# Altium schematic sheet IO_section.SchDoc (continued)

## Library data of the parts
C200 C205 = C0603C100J5GACTU: 10 pF ±5% 50V Ceramic Capacitor C0G, NP0 0603 (1608 Metric); Manufacturer: KEMET; Multilayer: Yes; Capacitor Type: Ceramic; Category: Ceramic Capacitors; Component Kind: Standard; ComponentLink1Description: https://datasheet.ciiva.com:443/pdfs/VipMasterIC/IC/KEME/KEME-S-A0005605168/KEME-S-A0005605168-1.pdf?src-supplier=IHS+Markit; ComponentLink1URL: https://datasheet.ciiva.com:443/pdfs/VipMasterIC/IC/KEME/KEME-S-A0005605168/KEME-S-A0005605168-1.pdf?src-supplier=IHS+Markit; ComponentLink2Description: https://datasheet.ciiva.com/7823/1725421-7823558.pdf?src-supplier=Element14; ComponentLink2URL: https://datasheet.ciiva.com/7823/1725421-7823558.pdf?src-supplier=Element14; ComponentLink3Description: https://datasheet.ciiva.com/7823/1725421-7823559.pdf?src-supplier=Element14; ComponentLink3URL: https://datasheet.ciiva.com/7823/1725421-7823559.pdf?src-supplier=Element14; ComponentLink4Description: https://datasheet.ciiva.com/14589/70095383-14589517.pdf?src-supplier=Allied+Electronics; ComponentLink4URL: https://datasheet.ciiva.com/14589/70095383-14589517.pdf?src-supplier=Allied+Electronics; ComponentLink5Description: https://datasheet.ciiva.com/22749/1372242-22749670.pdf?src-supplier=Element14; ComponentLink5URL: https://datasheet.ciiva.com/22749/1372242-22749670.pdf?src-supplier=Element14; ComponentLink6Description: https://datasheet.ciiva.com/18192/getdatasheetpartid-299146-18192613.pdf?src-supplier=Verical; ComponentLink6URL: https://datasheet.ciiva.com/18192/getdatasheetpartid-299146-18192613.pdf?src-supplier=Verical; ComponentLink7Description: https://datasheet.ciiva.com/18192/getdatasheetpartid-179326-18192615.pdf?src-supplier=Verical; ComponentLink7URL: https://datasheet.ciiva.com/18192/getdatasheetpartid-179326-18192615.pdf?src-supplier=Verical; Dielectric Material: Ceramic; Height: 0.8mm; JESD-609 Code: e3; Length: 1.6mm; Library Name: Components.IntLib; Manufacturer Part Number: C0603C100J5GACTU; Max Operating Temperature: 125°C; Min Operating Temperature: -55°C; Mounting Technology: Surface Mount; Package Shape: Rectangular; Package Style: SMTMeter; Packaging: Tape and Reel; Pins: 2; Pricing: 1=0.14, 10=0.055, 50=0.055, 100=0.032, 1000=0.027, 10000=0.019 (USD); Rated DC Voltage (URdc): 50V; Reach SVHC Compliant: Yes; RoHS: Yes; RoHS Compliant: Yes; Stock: 425955; Supplier: Mouser; Supplier Part Number: 80-C0603C100J5G; Temperature Characteristics Code: C0G; Terminal Finish: Nickel; Termination: Wraparound; Tolerance  (fill in as +-): 5%; Tolerance (fill in as +-): 5%; Width: 0.8mm
  C200: Case/Package: 0603; Value: 10pF
C201 C204 C206 C208 C210 C213 = C0603C104K5RACTU: 0.1µF ±10% 50V Ceramic Capacitor X7R 0603 (1608 Metric); Manufacturer: KEMET; Capacitance: 100nF; Dissipation Factor: 2.5%; Case Code (Metric): 1608; Case/Package: 0603; Category: Ceramic Capacitors; Component Kind: Standard; ComponentLink1Description: https://datasheet.ciiva.com/7823/kem-c1002-x7r-smd-7823591.pdf?src-supplier=Element14; ComponentLink1URL: https://datasheet.ciiva.com/7823/kem-c1002-x7r-smd-7823591.pdf?src-supplier=Element14; ComponentLink2Description: https://datasheet.ciiva.com/14602/70095383-14602583.pdf?src-supplier=Allied+Electronics; ComponentLink2URL: https://datasheet.ciiva.com/14602/70095383-14602583.pdf?src-supplier=Allied+Electronics; ComponentLink3Description: https://datasheet.ciiva.com/5999/kem-c1002-x7r-smd-5999400.pdf?src-supplier=Farnell; ComponentLink3URL: https://datasheet.ciiva.com/5999/kem-c1002-x7r-smd-5999400.pdf?src-supplier=Farnell; ComponentLink4Description: https://datasheet.ciiva.com/7823/kem-c1002-x7r-smd-7823592.pdf?src-supplier=Element14; ComponentLink4URL: https://datasheet.ciiva.com/7823/kem-c1002-x7r-smd-7823592.pdf?src-supplier=Element14; ComponentLink5Description: https://datasheet.ciiva.com/28645/1851736-28645640.pdf?src-supplier=Element14; ComponentLink5URL: https://datasheet.ciiva.com/28645/1851736-28645640.pdf?src-supplier=Element14; ComponentLink6Description: https://datasheet.ciiva.com/14585/70095383-14585209.pdf?src-supplier=Allied+Electronics; ComponentLink6URL: https://datasheet.ciiva.com/14585/70095383-14585209.pdf?src-supplier=Allied+Electronics; Contact Plating: Tin,Matte; Depth: 0.8mm; Dielectric: X7R; Dielectric Material: Ceramic; Height: 0.8mm; Lead Pitch: 0.7mm; Length: 0.063inch; Library Name: Components.IntLib; Manufacturer Part Number: C0603C104K5RACTU; Max Operating Temperature: 125°C; Min Operating Temperature: -55°C; Mount: Surface Mount; Number of Pins: 2; Package Quantity: 1; Packaging: Tape and Reel; Pricing 1: 1=0.12000, 10=0.08900, 50=0.04840, 100=0.03940, 500=0.02818, 1000=0.02214 (USD); Radiation Hardening: No; REACH SVHC: No SVHC; RoHS: Yes; RoHS Compliant: Yes; Series: SMD Comm X7R; Stock 1: 3160568; Supplier 1: Digi-Key; Supplier Part Number 1: 399-5089-1-ND; Termination: SMD/SMT; Thickness: 0.034inch; Tolerance: 10%; Voltage: 50V; Voltage Rating: 50V; Voltage Rating (DC): 50V; Width: 0.031inch
C202 C203 C209 C211 C212 = C0603C103K5RACTU: 10000pF ±10% 50V Ceramic Capacitor X7R 0603 (1608 Metric); Manufacturer: KEMET; Dissipation Factor: 2.5%; Case Code (Metric): 1608; Case/Package: 0603; Category: Ceramic Capacitors; Component Kind: Standard; ComponentLink1Description: https://datasheet.ciiva.com/7823/1732718-7823585.pdf?src-supplier=Element14; ComponentLink1URL: https://datasheet.ciiva.com/7823/1732718-7823585.pdf?src-supplier=Element14; ComponentLink2Description: https://datasheet.ciiva.com/5904/kem-c1002-x7r-smd-5904645.pdf?src-supplier=Farnell; ComponentLink2URL: https://datasheet.ciiva.com/5904/kem-c1002-x7r-smd-5904645.pdf?src-supplier=Farnell; ComponentLink3Description: https://datasheet.ciiva.com/18192/getdatasheetpartid-308803-18192662.pdf?src-supplier=Verical; ComponentLink3URL: https://datasheet.ciiva.com/18192/getdatasheetpartid-308803-18192662.pdf?src-supplier=Verical; ComponentLink4Description: https://datasheet.ciiva.com/39122/kem-c1002-x7r-smd-39122903.pdf?src-supplier=Onlinecomponents.com; ComponentLink4URL: https://datasheet.ciiva.com/39122/kem-c1002-x7r-smd-39122903.pdf?src-supplier=Onlinecomponents.com; ComponentLink5Description: https://datasheet.ciiva.com/7823/kem-c1002-x7r-smd-7823976.pdf?src-supplier=Element14; ComponentLink5URL: https://datasheet.ciiva.com/7823/kem-c1002-x7r-smd-7823976.pdf?src-supplier=Element14; ComponentLink6Description: https://datasheet.ciiva.com/7823/kem-c1002-x7r-smd-7823977.pdf?src-supplier=Element14; ComponentLink6URL: https://datasheet.ciiva.com/7823/kem-c1002-x7r-smd-7823977.pdf?src-supplier=Element14; ComponentLink7Description: https://datasheet.ciiva.com/7823/1918693-7823978.pdf?src-supplier=Element14; ComponentLink7URL: https://datasheet.ciiva.com/7823/1918693-7823978.pdf?src-supplier=Element14; ComponentLink8Description: https://datasheet.ciiva.com/14585/70095383-14585450.pdf?src-supplier=Allied+Electronics; ComponentLink8URL: https://datasheet.ciiva.com/14585/70095383-14585450.pdf?src-supplier=Allied+Electronics; ComponentLink9Description: https://datasheet.ciiva.com/18101/getdatasheetpartid-58468-18101898.pdf?src-supplier=Verical; ComponentLink9URL: https://datasheet.ciiva.com/18101/getdatasheetpartid-58468-18101898.pdf?src-supplier=Verical; ComponentLink10Description: https://datasheet.ciiva.com/5416/kem-c1002-x7r-smd-5416028.pdf?src-supplier=Mouser; ComponentLink10URL: https://datasheet.ciiva.com/5416/kem-c1002-x7r-smd-5416028.pdf?src-supplier=Mouser; ComponentLink11Description: https://datasheet.ciiva.com/28645/1851736-28645598.pdf?src-supplier=Element14; ComponentLink11URL: https://datasheet.ciiva.com/28645/1851736-28645598.pdf?src-supplier=Element14; Contact Plating: Tin,Matte; Depth: 0.8mm; Dielectric: X7R; Dielectric Material: Ceramic; Height: 0.8mm; Lead Pitch: 0.7mm; Length: 1.6mm; Library Name: Components.IntLib; Manufacturer Part Number: C0603C103K5RACTU; Max Operating Temperature: 125°C; Min Operating Temperature: -55°C; Mount: Surface Mount,PCB; Number of Pins: 2; Package Quantity: 4000; Packaging: Tape and Reel; Pricing 1: 1=0.10000, 10=0.07000, 50=0.03820, 100=0.03130, 500=0.02234, 1000=0.01755 (USD); Radiation Hardening: No; REACH SVHC: No SVHC; RoHS: Yes; Stock 1: 1389959; Supplier 1: Digi-Key; Supplier Part Number 1: 399-1091-1-ND; Termination: SMD/SMT; Thickness: 0.034inch; Tolerance: 10%; Voltage: 50V; Voltage Rating: 50V; Voltage Rating (DC): 50V; Width: 0.8mm
  C203 C212: Case Code (Imperial): 0603
C207 = GRM188R71A225KE15J: 2.2 µF ±10% 10V Ceramic Capacitor X7R 0603 (1608 Metric); Manufacturer: Murata; Multilayer: Yes; Capacitor Type: Ceramic; Case/Package: 0603; Category: Ceramic Capacitors; Component Kind: Standard; ComponentLink1Description: https://datasheet.ciiva.com:443/pdfs/VipMasterIC/IC/MURE/MURE-S-A0000034126/MURE-S-A0000034126-1.pdf?src-supplier=IHS+Markit; ComponentLink1URL: https://datasheet.ciiva.com:443/pdfs/VipMasterIC/IC/MURE/MURE-S-A0000034126/MURE-S-A0000034126-1.pdf?src-supplier=IHS+Markit; ComponentLink2Description: https://datasheet.ciiva.com/6264/grm188r71a225ke15-01-6264252.pdf?src-supplier=Digi-Key; ComponentLink2URL: https://datasheet.ciiva.com/6264/grm188r71a225ke15-01-6264252.pdf?src-supplier=Digi-Key; ComponentLink3Description: https://datasheet.ciiva.com/6264/grm188r71a225ke15-01-6264251.pdf?src-supplier=Digi-Key; ComponentLink3URL: https://datasheet.ciiva.com/6264/grm188r71a225ke15-01-6264251.pdf?src-supplier=Digi-Key; ComponentLink4Description: https://datasheet.ciiva.com/6264/grm188r71a225ke15-01-6264253.pdf?src-supplier=Digi-Key; ComponentLink4URL: https://datasheet.ciiva.com/6264/grm188r71a225ke15-01-6264253.pdf?src-supplier=Digi-Key; Dielectric Material: Ceramic; Height: 0.8mm; JESD-609 Code: e3; Length: 1.6mm; Library Name: Components.IntLib; Manufacturer Part Number: GRM188R71A225KE15J; Max Operating Temperature: 125°C; Min Operating Temperature: -55°C; Mounting Technology: Surface Mount; Package Shape: Rectangular; Package Style: SMTMeter; Packaging: Tape and Reel; Pins: 2; Pricing: 1=0.16, 10=0.117, 50=0.0664, 100=0.055, 500=0.03858, 1000=0.03194, 2500=0.02972, 5000=0.0272 (USD); Rated DC Voltage (URdc): 10V; Reach SVHC Compliant: Yes; RoHS: Yes; RoHS Compliant: Yes; Stock: 2026591; Supplier: Digi-Key; Supplier Part Number: 490-6418-1-ND; Temperature Characteristics Code: X7R; Terminal Finish: Nickel; Termination: Wraparound; Tolerance  (fill in as +-): 10%; Tolerance (fill in as +-): 10%; Width: 0.8mm
D200 = PUSB3FR4Z: 3V (Typ) Clamp 5A (8/20µs) Ipp Tvs Diode Surface Mount DFN2510A-10; Manufacturer: Nexperia; Diode Element Material: SILICON; Diode Type: TRANS VOLTAGE SUPPRESSOR; Min Breakdown Voltage: 5.5V; Number of Elements: 4; Package Body Material: Plastic; Polarity: Unidirectional; Reference Standard: IEC-60134; Category: ESD and Circuit Protection ICs; Component Kind: Standard; ComponentLink1Description: https://datasheet.ciiva.com:443/pdfs/VipMasterIC/IC/NEXP/NEXP-S-A0002907422/NEXP-S-A0002907422-1.pdf?src-supplier=IHS+Markit; ComponentLink1URL: https://datasheet.ciiva.com:443/pdfs/VipMasterIC/IC/NEXP/NEXP-S-A0002907422/NEXP-S-A0002907422-1.pdf?src-supplier=IHS+Markit; ComponentLink2Description: http://www.farnell.com/datasheets/1961315.pdf?src-supplier=Farnell; ComponentLink2URL: http://www.farnell.com/datasheets/1961315.pdf?src-supplier=Farnell; ComponentLink3Description: https://assets.nexperia.com/documents/data-sheet/PUSB3FR4.pdf?src-supplier=Digi-Key; ComponentLink3URL: https://assets.nexperia.com/documents/data-sheet/PUSB3FR4.pdf?src-supplier=Digi-Key; ComponentLink4Description: http://www.farnell.com/datasheets/1961315.pdf?src-supplier=Element14; ComponentLink4URL: http://www.farnell.com/datasheets/1961315.pdf?src-supplier=Element14; ComponentLink5Description: https://datasheet.octopart.com/PUSB3FR4Z-Nexperia-datasheet-37004474.pdf?src-supplier=Future+Electronics; ComponentLink5URL: https://datasheet.octopart.com/PUSB3FR4Z-Nexperia-datasheet-37004474.pdf?src-supplier=Future+Electronics; ComponentLink6Description: http://datasheet.octopart.com/PUSB3FR4Z-Nexperia-datasheet-87845463.pdf?src-supplier=Digi-Key; ComponentLink6URL: http://datasheet.octopart.com/PUSB3FR4Z-Nexperia-datasheet-87845463.pdf?src-supplier=Digi-Key; ComponentLink7Description: http://datasheet.octopart.com/PUSB3FR4Z-Nexperia-datasheet-87845463.pdf?src-supplier=Rs; ComponentLink7URL: http://datasheet.octopart.com/PUSB3FR4Z-Nexperia-datasheet-87845463.pdf?src-supplier=Rs; ComponentLink8Description: http://datasheet.octopart.com/PUSB3FR4Z-Nexperia-datasheet-87845463.pdf?src-supplier=Avnet; ComponentLink8URL: http://datasheet.octopart.com/PUSB3FR4Z-Nexperia-datasheet-87845463.pdf?src-supplier=Avnet; ComponentLink9Description: http://datasheet.octopart.com/PUSB3FR4Z-Nexperia-datasheet-87845463.pdf?src-supplier=Verical; ComponentLink9URL: http://datasheet.octopart.com/PUSB3FR4Z-Nexperia-datasheet-87845463.pdf?src-supplier=Verical; ComponentLink10Description: http://datasheet.octopart.com/PUSB3FR4Z-Nexperia-datasheet-87845463.pdf?src-supplier=Future+Electronics; ComponentLink10URL: http://datasheet.octopart.com/PUSB3FR4Z-Nexperia-datasheet-87845463.pdf?src-supplier=Future+Electronics; ComponentLink11Description: http://www.farnell.com/datasheets/1961315.pdf?src-supplier=Newark; ComponentLink11URL: http://www.farnell.com/datasheets/1961315.pdf?src-supplier=Newark; ComponentLink12Description: http://datasheet.octopart.com/PUSB3FR4Z-Nexperia-datasheet-87845463.pdf?src-supplier=Mouser; ComponentLink12URL: http://datasheet.octopart.com/PUSB3FR4Z-Nexperia-datasheet-87845463.pdf?src-supplier=Mouser; ComponentLink13Description: https://datasheet.octopart.com/PUSB3FR4Z-Nexperia-datasheet-155953997.pdf?src-supplier=TME; ComponentLink13URL: https://datasheet.octopart.com/PUSB3FR4Z-Nexperia-datasheet-155953997.pdf?src-supplier=TME; ComponentLink14Description: http://datasheet.octopart.com/PUSB3FR4Z-Nexperia-datasheet-87845463.pdf?src-supplier=TTI; ComponentLink14URL: http://datasheet.octopart.com/PUSB3FR4Z-Nexperia-datasheet-87845463.pdf?src-supplier=TTI; Library Name: Components.SchLib; Manufacturer Part Number: PUSB3FR4Z; Max Operating Temperature: 85°C; Min Operating Temperature: -40°C; Package Shape: Rectangular; Package Style: SMALL OUTLINEMeter; Pins: 10; Pricing: 1=0.43, 10=0.349, 25=0.3192, 100=0.2376, 250=0.21528, 500=0.17816, 1000=0.13362, 2500=0.12249 (USD); Reach SVHC Compliant: Yes; RoHS: Yes; RoHS Compliant: Yes; Stock: 26815; Supplier: Digi-Key; Supplier Part Number: 1727-2026-1-ND; Surface Mount: Yes; Technology: AVALANCHE; Terminal Form: No Lead; Terminal Position: DUAL
D201 = LTST-C191KFKT: Orange 605nm LED Indication - Discrete 2V 0603 (1608 Metric); Manufacturer: Vishay Lite-On; Forward Current: 30mA; Lens Color: Colorless; Lens Style: Diffused,Colorless,Rectangular; Lens Transparency: Clear; Luminous Intensity: 90mcd; Number of Elements: 1; Power Dissipation: 75mW; Reverse Voltage: 5V; Test Current: 20mA; Viewing Angle: 130°; Wavelength: 611nm; Case Code (Metric): 1608; Case/Package: 0603; Category: LEDs; Color: Orange; Component Kind: Standard; ComponentLink1Description: https://datasheet.ciiva.com/2109/ltst-c191kfkt-2109968.pdf?src-supplier=Digi-Key; ComponentLink1URL: https://datasheet.ciiva.com/2109/ltst-c191kfkt-2109968.pdf?src-supplier=Digi-Key; ComponentLink2Description: https://datasheet.ciiva.com/12400/100-ltst-c191kfkt-39904-12400811.pdf?src-supplier=Mouser; ComponentLink2URL: https://datasheet.ciiva.com/12400/100-ltst-c191kfkt-39904-12400811.pdf?src-supplier=Mouser; ComponentLink3Description: https://datasheet.ciiva.com/11775/0900766b80dc02b0-11775613.pdf?src-supplier=RSComponents; ComponentLink3URL: https://datasheet.ciiva.com/11775/0900766b80dc02b0-11775613.pdf?src-supplier=RSComponents; ComponentLink4Description: https://datasheet.ciiva.com/15201/getdatasheetpartid-631075-15201391.pdf?src-supplier=Verical; ComponentLink4URL: https://datasheet.ciiva.com/15201/getdatasheetpartid-631075-15201391.pdf?src-supplier=Verical; ComponentLink5Description: https://datasheet.ciiva.com/2109/s-110-ltst-c191kfkt-2109969.pdf?src-supplier=Digi-Key; ComponentLink5URL: https://datasheet.ciiva.com/2109/s-110-ltst-c191kfkt-2109969.pdf?src-supplier=Digi-Key; Depth: 0.8mm; Dominant Wavelength: 605nm; Forward Voltage: 2V; Height: 0.55mm; Illumination Color: Orange; Lead Free: Lead Free; Length: 1.6mm; Library Name: Components.IntLib; Manufacturer Part Number: LTST-C191KFKT; Max Operating Temperature: 85°C; Min Operating Temperature: -55°C; Mount: Surface Mount; Number of LEDs: 1; Number of Pins: 2; Package Quantity: 1; Packaging: Tape and Reel; Power Rating: 75mW; Pricing 1: 1=0.24000, 10=0.13200, 100=0.06110, 500=0.05640, 1000=0.04418, 2000=0.04324 (USD); Radiation Hardening: No; RoHS: Yes; RoHS Compliant: Yes; Stock 1: 7508; Supplier 1: Digi-Key; Supplier Part Number 1: 160-1445-1-ND; Width: 0.8mm
D202 = LTST-C193TBKT-5A: Blue 470nm LED Indication - Discrete 2.8V 0603 (1608 Metric); Manufacturer: Vishay Lite-On; Channels: 1; Forward Current: 20mA; Lens Style: WATER CLEAR; Luminous Intensity-Nom: 15mcd; Optoelectronic Device Type: SINGLE COLOR LED; Overall Height: 0.35mm; Shape: Rectangular; Viewing Angle: 130°; Category: LEDs; Color: Blue; Component Kind: Standard; ComponentLink1Description: https://datasheet.ciiva.com:443/pdfs/VipMasterIC/IC/LITE/LITES12050/LITES12050-1.pdf?src-supplier=IHS+Markit; ComponentLink1URL: https://datasheet.ciiva.com:443/pdfs/VipMasterIC/IC/LITE/LITES12050/LITES12050-1.pdf?src-supplier=IHS+Markit; ComponentLink2Description: https://datasheet.ciiva.com/2111/ltst-c193tbkt-5a-2111219.pdf?src-supplier=Digi-Key; ComponentLink2URL: https://datasheet.ciiva.com/2111/ltst-c193tbkt-5a-2111219.pdf?src-supplier=Digi-Key; ComponentLink3Description: https://datasheet.ciiva.com/16210/ltst-c193tbkt-5a-16210824.pdf?src-supplier=Future+Electronics; ComponentLink3URL: https://datasheet.ciiva.com/16210/ltst-c193tbkt-5a-16210824.pdf?src-supplier=Future+Electronics; ComponentLink4Description: https://datasheet.ciiva.com/15201/getdatasheetpartid-293056-15201395.pdf?src-supplier=Verical; ComponentLink4URL: https://datasheet.ciiva.com/15201/getdatasheetpartid-293056-15201395.pdf?src-supplier=Verical; ComponentLink5Description: https://datasheet.ciiva.com/11773/0900766b80dc0554-11773880.pdf?src-supplier=Rs; ComponentLink5URL: https://datasheet.ciiva.com/11773/0900766b80dc0554-11773880.pdf?src-supplier=Rs; ComponentLink6Description: https://datasheet.ciiva.com/4688/lite-on-ltst-c193tbkt-5a-187386-4688832.pdf?src-supplier=Mouser; ComponentLink6URL: https://datasheet.ciiva.com/4688/lite-on-ltst-c193tbkt-5a-187386-4688832.pdf?src-supplier=Mouser; ComponentLink7Description: https://datasheet.ciiva.com/2111/p-100-ltst-c193tbkt-5a-2111220.pdf?src-supplier=Digi-Key; ComponentLink7URL: https://datasheet.ciiva.com/2111/p-100-ltst-c193tbkt-5a-2111220.pdf?src-supplier=Digi-Key; ComponentLink8Description: https://datasheet.ciiva.com/2111/p-100-ltst-c193tbkt-5a-2111221.pdf?src-supplier=Digi-Key; ComponentLink8URL: https://datasheet.ciiva.com/2111/p-100-ltst-c193tbkt-5a-2111221.pdf?src-supplier=Digi-Key; JESD-609 Code: e3; Library Name: Components.IntLib; Manufacturer Part Number: LTST-C193TBKT-5A; Max Operating Temperature: 80°C; Min Operating Temperature: -20°C; Mounting Technology: Surface Mount; Packaging: Tape and Reel; Pins: 2; Pricing: 1=0.3, 10=0.236, 100=0.1061, 500=0.09586, 1000=0.07875, 2000=0.06848 (USD); Reach SVHC Compliant: Yes; RoHS: Yes; RoHS Compliant: Yes; Size: 1.15mm; Stock: 110301; Supplier: Digi-Key; Supplier Part Number: 160-1827-1-ND; Terminal Finish: Tin; Terminal Pitch: 1.5mm
J200 = SM06B-SRSS-TB: Connector Header Surface Mount, Right Angle 6 position 0.039" (1.00mm); Manufacturer: JST; Category: Connectors; Component Kind: Standard; ComponentLink1Description: https://datasheet.ciiva.com/6905/srconnector-6905307.pdf?src-supplier=Digi-Key; ComponentLink1URL: https://datasheet.ciiva.com/6905/srconnector-6905307.pdf?src-supplier=Digi-Key; ComponentLink2Description: https://datasheet.ciiva.com/6905/srconnector-6905305.pdf?src-supplier=Digi-Key; ComponentLink2URL: https://datasheet.ciiva.com/6905/srconnector-6905305.pdf?src-supplier=Digi-Key; Library Name: Components.IntLib; Manufacturer Part Number: SM06B-SRSS-TB; Pricing: 1=0.78, 10=0.678, 100=0.5587, 500=0.48582, 1000=0.4008 (USD); RoHS: No; Stock: 44917; Supplier: Digi-Key; Supplier Part Number: 455-SM06B-SRSS-TBCT-ND
Q200 = DMG2302UKQ-7: N-Channel 20V 2.8A (Ta) 660mW (Ta) Surface Mount SOT-23; Manufacturer: Diodes; Input Capacitance: 130pF; Rds On Max: 90mR; Case/Package: SOT-23; Component Kind: Standard; Continuous Drain Current (ID): 2.8A; Drain to Source Voltage (Vdss): 20V; Library Name: Components.IntLib; Manufacturer Part Number: DMG2302UKQ-7; Max Operating Temperature: 150°C; Max Power Dissipation: 660mW; Min Operating Temperature: -55°C; Mount: Surface Mount; Packaging: Tape and Reel; Pricing 1: 5=0.61900, 10=0.42500, 25=0.36100, 50=0.29800, 100=0.23400 (USD); RoHS: Yes; Supplier 1: Newark; Supplier Part Number 1: 88AH6197
R200 R202 R206 R209 = CRCW040210K0FKED: Thick Film Resistors - SMD 1/16watt 10Kohms 1%; Manufacturer: Vishay; Package Height: 0.35mm; Package Length: 1mm; Package Width: 0.5mm; Power: 63mW; Rated Temperature: 70°C; Reference Standard: AEC-Q200; Temperature Coefficient: 100ppm/°C; Value: 10kR; Working Voltage: 50V; Category: Chip SMD Resistors; Component Kind: Standard; ComponentLink1Description: https://datasheet.ciiva.com:443/pdfs/VipMasterIC/IC/VISH/VISH-S-A0007266705/VISH-S-A0007266705-1.pdf?src-supplier=IHS+Markit; ComponentLink1URL: https://datasheet.ciiva.com:443/pdfs/VipMasterIC/IC/VISH/VISH-S-A0007266705/VISH-S-A0007266705-1.pdf?src-supplier=IHS+Markit; ComponentLink2Description: http://www.farnell.com/datasheets/2714353.pdf?src-supplier=Farnell; ComponentLink2URL: http://www.farnell.com/datasheets/2714353.pdf?src-supplier=Farnell; ComponentLink3Description: http://www.farnell.com/datasheets/2793682.pdf?src-supplier=Farnell; ComponentLink3URL: http://www.farnell.com/datasheets/2793682.pdf?src-supplier=Farnell; ComponentLink4Description: http://www.farnell.com/datasheets/2714353.pdf?src-supplier=Newark; ComponentLink4URL: http://www.farnell.com/datasheets/2714353.pdf?src-supplier=Newark; ComponentLink5Description: https://datasheet.octopart.com/CRCW040210K0FKED-Vishay-datasheet-5300368.pdf?src-supplier=Future+Electronics; ComponentLink5URL: https://datasheet.octopart.com/CRCW040210K0FKED-Vishay-datasheet-5300368.pdf?src-supplier=Future+Electronics; ComponentLink6Description: http://datasheet.octopart.com/CRCW040210K0FKED-Vishay-datasheet-62317933.pdf?src-supplier=Digi-Key; ComponentLink6URL: http://datasheet.octopart.com/CRCW040210K0FKED-Vishay-datasheet-62317933.pdf?src-supplier=Digi-Key; ComponentLink7Description: https://datasheet.octopart.com/CRCW040210K0FKED-Vishay-datasheet-83961561.pdf?src-supplier=Element14; ComponentLink7URL: https://datasheet.octopart.com/CRCW040210K0FKED-Vishay-datasheet-83961561.pdf?src-supplier=Element14; ComponentLink8Description: http://www.farnell.com/datasheets/2714353.pdf?src-supplier=Element14; ComponentLink8URL: http://www.farnell.com/datasheets/2714353.pdf?src-supplier=Element14; ComponentLink9Description: http://datasheet.octopart.com/CRCW040210K0FKED-Vishay-datasheet-62317933.pdf?src-supplier=Rs; ComponentLink9URL: http://datasheet.octopart.com/CRCW040210K0FKED-Vishay-datasheet-62317933.pdf?src-supplier=Rs; ComponentLink10Description: http://datasheet.octopart.com/CRCW040210K0FKED-Vishay-datasheet-62317933.pdf?src-supplier=Avnet; ComponentLink10URL: http://datasheet.octopart.com/CRCW040210K0FKED-Vishay-datasheet-62317933.pdf?src-supplier=Avnet; ComponentLink11Description: http://www.farnell.com/datasheets/2793682.pdf?src-supplier=Newark; ComponentLink11URL: http://www.farnell.com/datasheets/2793682.pdf?src-supplier=Newark; ComponentLink12Description: https://datasheet.octopart.com/CRCW040210K0FKED.-Vishay-datasheet-78776209.pdf?src-supplier=Newark; ComponentLink12URL: https://datasheet.octopart.com/CRCW040210K0FKED.-Vishay-datasheet-78776209.pdf?src-supplier=Newark; ComponentLink13Description: http://datasheet.octopart.com/CRCW040210K0FKED-Vishay-datasheet-62317933.pdf?src-supplier=Distrelec; ComponentLink13URL: http://datasheet.octopart.com/CRCW040210K0FKED-Vishay-datasheet-62317933.pdf?src-supplier=Distrelec; ComponentLink14Description: http://datasheet.octopart.com/CRCW040210K0FKED-Vishay-datasheet-62317933.pdf?src-supplier=Mouser; ComponentLink14URL: http://datasheet.octopart.com/CRCW040210K0FKED-Vishay-datasheet-62317933.pdf?src-supplier=Mouser; ComponentLink15Description: http://datasheet.octopart.com/CRCW040210K0FKED-Vishay-datasheet-62317933.pdf?src-supplier=Quest; ComponentLink15URL: http://datasheet.octopart.com/CRCW040210K0FKED-Vishay-datasheet-62317933.pdf?src-supplier=Quest; ComponentLink16Description: http://datasheet.octopart.com/CRCW040210K0FKED-Vishay-datasheet-62317933.pdf?src-supplier=TTI; ComponentLink16URL: http://datasheet.octopart.com/CRCW040210K0FKED-Vishay-datasheet-62317933.pdf?src-supplier=TTI; ComponentLink17Description: http://datasheet.octopart.com/CRCW040210K0FKED-Vishay-datasheet-62317933.pdf?src-supplier=Freelance+Electronics; ComponentLink17URL: http://datasheet.octopart.com/CRCW040210K0FKED-Vishay-datasheet-62317933.pdf?src-supplier=Freelance+Electronics; ComponentLink18Description: https://datasheet.octopart.com/CRCW040210K0FKED-Vishay-datasheet-134526670.pdf?src-supplier=TME; ComponentLink18URL: https://datasheet.octopart.com/CRCW040210K0FKED-Vishay-datasheet-134526670.pdf?src-supplier=TME; Construction: Chip; JESD-609 Code: e3; Library Name: Components.IntLib; Manufacturer Part Number: CRCW040210K0FKED; Max Operating Temperature: 155°C; Min Operating Temperature: -55°C; Mounting Technology: Surface Mount; Package Shape: Rectangular; Package Style: SMTMeter; Packaging: Tape and Reel; Pins: 2; Pricing: 1=0.1, 10=0.019, 50=0.019, 100=0.009, 1000=0.006, 10000=0.003 (USD); Reach SVHC Compliant: Yes; Resistor Type: FIXED RESISTOR; RoHS: Yes; RoHS Compliant: Yes; Stock: 1050191; Supplier: Mouser; Supplier Part Number: 71-CRCW0402-10K-E3; Technology: METAL GLAZE/THICK FILM; Terminal Finish: Nickel; Termination: Wraparound; Tolerance: 1%
R201 = CRCW040220K0FKED: 20 kOhms ±1% 0.063W, 1/16W Chip Resistor 0402 (1005 Metric) Automotive AEC-Q200 Thick Film; Manufacturer: Vishay; Package Height: 0.35mm; Package Length: 1mm; Package Width: 0.5mm; Power: 63mW; Rated Temperature: 70°C; Temperature Coefficient: 100ppm/°C; Value: 20kR; Working Voltage: 50V; Category: Chip SMD Resistors; Component Kind: Standard; ComponentLink1Description: https://datasheet.ciiva.com:443/pdfs/VipMasterIC/IC/VISH/VISH-S-A0007266705/VISH-S-A0007266705-1.pdf?src-supplier=IHS+Markit; ComponentLink1URL: https://datasheet.ciiva.com:443/pdfs/VipMasterIC/IC/VISH/VISH-S-A0007266705/VISH-S-A0007266705-1.pdf?src-supplier=IHS+Markit; ComponentLink2Description: https://datasheet.ciiva.com/2463/dcrcwe3-2463140.pdf?src-supplier=Digi-Key; ComponentLink2URL: https://datasheet.ciiva.com/2463/dcrcwe3-2463140.pdf?src-supplier=Digi-Key; ComponentLink3Description: https://datasheet.ciiva.com/2463/dcrcwe3-2463141.pdf?src-supplier=Digi-Key; ComponentLink3URL: https://datasheet.ciiva.com/2463/dcrcwe3-2463141.pdf?src-supplier=Digi-Key; ComponentLink4Description: https://datasheet.ciiva.com/2463/dcrcwe3-2463139.pdf?src-supplier=Digi-Key; ComponentLink4URL: https://datasheet.ciiva.com/2463/dcrcwe3-2463139.pdf?src-supplier=Digi-Key; Construction: Chip; JESD-609 Code: e3; Library Name: Components.IntLib; Manufacturer Part Number: CRCW040220K0FKED; Max Operating Temperature: 155°C; Min Operating Temperature: -55°C; Mounting Technology: Surface Mount; Package Shape: Rectangular; Package Style: SMTMeter; Packaging: Tape and Reel; Pins: 2; Pricing: 1=0.1, 10=0.033, 50=0.0182, 100=0.0134, 500=0.00818, 1000=0.00602, 5000=0.00432 (USD); Reach SVHC Compliant: Yes; Reference Standard: AEC-Q200; Resistor Type: FIXED RESISTOR; RoHS: Yes; RoHS Compliant: Yes; Stock: 1159598; Supplier: Digi-Key; Supplier Part Number: 541-20.0KLCT-ND; Technology: METAL GLAZE/THICK FILM; Terminal Finish: Nickel; Termination: Wraparound; Tolerance: 1%
R203 R205 R207 R208 = CRCW0402120RFKED: 120 Ohms ±1% 0.063W, 1/16W Chip Resistor 0402 (1005 Metric) Automotive AEC-Q200 Thick Film; Manufacturer: Vishay; Category: Chip SMD Resistors; Component Kind: Standard; ComponentLink1Description: https://datasheet.ciiva.com/11817/0900766b80d98824-11817989.pdf?src-supplier=Rs; ComponentLink1URL: https://datasheet.ciiva.com/11817/0900766b80d98824-11817989.pdf?src-supplier=Rs; ComponentLink2Description: https://datasheet.ciiva.com/2463/dcrcwe3-2463110.pdf?src-supplier=Digi-Key; ComponentLink2URL: https://datasheet.ciiva.com/2463/dcrcwe3-2463110.pdf?src-supplier=Digi-Key; ComponentLink3Description: https://datasheet.ciiva.com/2463/dcrcwe3-2463109.pdf?src-supplier=Digi-Key; ComponentLink3URL: https://datasheet.ciiva.com/2463/dcrcwe3-2463109.pdf?src-supplier=Digi-Key; ComponentLink4Description: https://datasheet.ciiva.com/2463/dcrcwe3-2463111.pdf?src-supplier=Digi-Key; ComponentLink4URL: https://datasheet.ciiva.com/2463/dcrcwe3-2463111.pdf?src-supplier=Digi-Key; ComponentLink5Description: https://datasheet.ciiva.com/3256/dcrcwe3-3256009.pdf?src-supplier=Avnet; ComponentLink5URL: https://datasheet.ciiva.com/3256/dcrcwe3-3256009.pdf?src-supplier=Avnet; ComponentLink6Description: https://datasheet.ciiva.com/8336/dcrcwe3-8336661.pdf?src-supplier=Avnet; ComponentLink6URL: https://datasheet.ciiva.com/8336/dcrcwe3-8336661.pdf?src-supplier=Avnet; ComponentLink7Description: https://datasheet.ciiva.com/22674/1912744-22674687.pdf?src-supplier=Element14; ComponentLink7URL: https://datasheet.ciiva.com/22674/1912744-22674687.pdf?src-supplier=Element14; ComponentLink8Description: https://datasheet.ciiva.com/7777/1697337-7777194.pdf?src-supplier=Element14; ComponentLink8URL: https://datasheet.ciiva.com/7777/1697337-7777194.pdf?src-supplier=Element14; ComponentLink9Description: https://datasheet.ciiva.com/7777/1697337-7777195.pdf?src-supplier=Element14; ComponentLink9URL: https://datasheet.ciiva.com/7777/1697337-7777195.pdf?src-supplier=Element14; ComponentLink10Description: https://datasheet.ciiva.com/5550/dcrcwe3-109170-5550502.pdf?src-supplier=Mouser; ComponentLink10URL: https://datasheet.ciiva.com/5550/dcrcwe3-109170-5550502.pdf?src-supplier=Mouser; Library Name: Components.IntLib; Manufacturer Part Number: CRCW0402120RFKED; Pricing: 1=0.1, 10=0.033, 50=0.0182, 100=0.0134, 500=0.00818, 1000=0.00602, 5000=0.00432 (USD); RoHS: Yes; Stock: 24743; Supplier: Digi-Key; Supplier Part Number: 541-120LCT-ND
R204 = CRCW0402100KFKED: Thick Film Resistors - SMD 1/16watt 100Kohms 1%; Manufacturer: Vishay; Package Height: 0.35mm; Package Length: 1mm; Package Width: 0.5mm; Power: 63mW; Rated Temperature: 70°C; Reference Standard: AEC-Q200; Temperature Coefficient: 100ppm/°C; Value: 100kR; Working Voltage: 50V; Category: Chip SMD Resistors; Component Kind: Standard; ComponentLink1Description: https://datasheet.ciiva.com:443/pdfs/VipMasterIC/IC/VISH/VISH-S-A0007266705/VISH-S-A0007266705-1.pdf?src-supplier=IHS+Markit; ComponentLink1URL: https://datasheet.ciiva.com:443/pdfs/VipMasterIC/IC/VISH/VISH-S-A0007266705/VISH-S-A0007266705-1.pdf?src-supplier=IHS+Markit; ComponentLink2Description: https://datasheet.ciiva.com/11810/0900766b80d98824-11810752.pdf?src-supplier=Rs; ComponentLink2URL: https://datasheet.ciiva.com/11810/0900766b80d98824-11810752.pdf?src-supplier=Rs; ComponentLink3Description: https://datasheet.ciiva.com/46441/1720486-46441598.pdf?src-supplier=Element14; ComponentLink3URL: https://datasheet.ciiva.com/46441/1720486-46441598.pdf?src-supplier=Element14; ComponentLink4Description: https://datasheet.ciiva.com/26316/fld-crcw-e3-data-en-26316630.pdf?src-supplier=Distrelec; ComponentLink4URL: https://datasheet.ciiva.com/26316/fld-crcw-e3-data-en-26316630.pdf?src-supplier=Distrelec; ComponentLink5Description: https://datasheet.ciiva.com/2463/dcrcwe3-2463064.pdf?src-supplier=Digi-Key; ComponentLink5URL: https://datasheet.ciiva.com/2463/dcrcwe3-2463064.pdf?src-supplier=Digi-Key; ComponentLink6Description: https://datasheet.ciiva.com/16776/70201119-16776281.pdf?src-supplier=Allied+Electronics; ComponentLink6URL: https://datasheet.ciiva.com/16776/70201119-16776281.pdf?src-supplier=Allied+Electronics; ComponentLink7Description: https://datasheet.ciiva.com/3255/dcrcwe3-3255947.pdf?src-supplier=Avnet; ComponentLink7URL: https://datasheet.ciiva.com/3255/dcrcwe3-3255947.pdf?src-supplier=Avnet; ComponentLink8Description: https://datasheet.ciiva.com/2463/dcrcwe3-2463065.pdf?src-supplier=Digi-Key; ComponentLink8URL: https://datasheet.ciiva.com/2463/dcrcwe3-2463065.pdf?src-supplier=Digi-Key; ComponentLink9Description: https://datasheet.ciiva.com/2463/dcrcwe3-2463066.pdf?src-supplier=Digi-Key; ComponentLink9URL: https://datasheet.ciiva.com/2463/dcrcwe3-2463066.pdf?src-supplier=Digi-Key; ComponentLink10Description: https://datasheet.ciiva.com/5546/dcrcwe3-109170-5546638.pdf?src-supplier=Mouser; ComponentLink10URL: https://datasheet.ciiva.com/5546/dcrcwe3-109170-5546638.pdf?src-supplier=Mouser; ComponentLink11Description: https://datasheet.ciiva.com/3255/dcrcwe3-3255948.pdf?src-supplier=Avnet; ComponentLink11URL: https://datasheet.ciiva.com/3255/dcrcwe3-3255948.pdf?src-supplier=Avnet; ComponentLink12Description: https://datasheet.ciiva.com/16208/dcrcwe3-16208508.pdf?src-supplier=Future+Electronics; ComponentLink12URL: https://datasheet.ciiva.com/16208/dcrcwe3-16208508.pdf?src-supplier=Future+Electronics; Construction: Chip; JESD-609 Code: e3; Library Name: Components.IntLib; Manufacturer Part Number: CRCW0402100KFKED; Max Operating Temperature: 155°C; Min Operating Temperature: -55°C; Mounting Technology: Surface Mount; Package Shape: Rectangular; Package Style: SMTMeter; Packaging: Tape and Reel; Pins: 2; Pricing: 1=0.1, 10=0.019, 50=0.019, 100=0.009, 1000=0.006, 10000=0.004 (USD); Reach SVHC Compliant: Yes; Resistor Type: FIXED RESISTOR; RoHS: Yes; RoHS Compliant: Yes; Stock: 615419; Supplier: Mouser; Supplier Part Number: 71-CRCW0402-100K-E3; Technology: METAL GLAZE/THICK FILM; Terminal Finish: Nickel; Termination: Wraparound; Tolerance: 1%
R211 = CRCW04022K20FKED: 2.2 kOhms ±1% 0.063W, 1/16W Chip Resistor 0402 (1005 Metric) Automotive AEC-Q200 Thick Film; Manufacturer: Vishay; Max Power Dissipation: 63mW; Temperature Coefficient: 100ppm/°C; Value: 2.2kR; Voltage Rating: 50V; Case Code (Metric): 1005; Case/Package: 0402; Category: Chip SMD Resistors; Component Kind: Standard; ComponentLink1Description: https://datasheet.ciiva.com/11811/0900766b80d98824-11811709.pdf?src-supplier=Rs; ComponentLink1URL: https://datasheet.ciiva.com/11811/0900766b80d98824-11811709.pdf?src-supplier=Rs; ComponentLink2Description: https://datasheet.ciiva.com/26316/fld-crcw-e3-data-en-26316650.pdf?src-supplier=Distrelec; ComponentLink2URL: https://datasheet.ciiva.com/26316/fld-crcw-e3-data-en-26316650.pdf?src-supplier=Distrelec; ComponentLink3Description: https://datasheet.ciiva.com/7777/1697337-7777228.pdf?src-supplier=Element14; ComponentLink3URL: https://datasheet.ciiva.com/7777/1697337-7777228.pdf?src-supplier=Element14; ComponentLink4Description: https://datasheet.ciiva.com/7777/1697337-7777227.pdf?src-supplier=Element14; ComponentLink4URL: https://datasheet.ciiva.com/7777/1697337-7777227.pdf?src-supplier=Element14; ComponentLink5Description: https://datasheet.ciiva.com/2464/dcrcwe3-2464486.pdf?src-supplier=Digi-Key; ComponentLink5URL: https://datasheet.ciiva.com/2464/dcrcwe3-2464486.pdf?src-supplier=Digi-Key; ComponentLink6Description: https://datasheet.ciiva.com/5541/dcrcwe3-109170-5541416.pdf?src-supplier=Mouser; ComponentLink6URL: https://datasheet.ciiva.com/5541/dcrcwe3-109170-5541416.pdf?src-supplier=Mouser; ComponentLink7Description: https://datasheet.ciiva.com/3256/dcrcwe3-3256341.pdf?src-supplier=Avnet; ComponentLink7URL: https://datasheet.ciiva.com/3256/dcrcwe3-3256341.pdf?src-supplier=Avnet; ComponentLink8Description: https://datasheet.ciiva.com/16209/dcrcwe3-16209157.pdf?src-supplier=Future+Electronics; ComponentLink8URL: https://datasheet.ciiva.com/16209/dcrcwe3-16209157.pdf?src-supplier=Future+Electronics; ComponentLink9Description: https://datasheet.ciiva.com/2464/dcrcwe3-2464487.pdf?src-supplier=Digi-Key; ComponentLink9URL: https://datasheet.ciiva.com/2464/dcrcwe3-2464487.pdf?src-supplier=Digi-Key; ComponentLink10Description: https://datasheet.ciiva.com/2464/dcrcwe3-2464488.pdf?src-supplier=Digi-Key; ComponentLink10URL: https://datasheet.ciiva.com/2464/dcrcwe3-2464488.pdf?src-supplier=Digi-Key; ComponentLink11Description: https://datasheet.ciiva.com/16778/70201119-16778943.pdf?src-supplier=Allied+Electronics; ComponentLink11URL: https://datasheet.ciiva.com/16778/70201119-16778943.pdf?src-supplier=Allied+Electronics; Construction: Rectangular; Contact Plating: Tin; Depth: 0.5mm; Features: Automotive AEC-Q200; Height: 0.35mm; Lead Free: Lead Free; Length: 1mm; Library Name: Components.IntLib; Manufacturer Part Number: CRCW04022K20FKED; Max Operating Temperature: 155°C; Military Standard: Not; Min Operating Temperature: -55°C; Number of Terminations: 2; Package Quantity: 10; Packaging: Tape and Reel; Power Rating: 63mW; Pricing: 1=0.1, 10=0.033, 50=0.0182, 100=0.0134, 500=0.00818, 1000=0.00602, 5000=0.00432 (USD); Radiation Hardening: No; Ratings: AEC-Q200; Reach SVHC Compliant: Unknown; Resistance Tolerance: 1%; Resistor Type: Thick Film; RoHS: Yes; RoHS Compliant: Yes; Series: CRCW; Stock: 1272851; Supplier: Digi-Key; Supplier Part Number: 541-2.20KLCT-ND; Technology: THICK FILM; Termination: SMD/SMT; Tolerance: 1%; Voltage Rating (DC): 50V; Weight: 2.3E-05oz; Width: 0.02inch
U200 = STM32F103C8T6: ARM® Cortex®-M3 STM32F1 Microcontroller IC 32-Bit Single-Core 72MHz 64KB (64K x 8) FLASH; Manufacturer: STMicroelectronics; Clock Frequency: 16MHz; Max Supply Current: 50mA; Max Supply Voltage: 3.6V; Min Supply Voltage: 2V; Nominal Supply Voltage: 3.3V; Number of I/O Lines: 37; Package Body Material: Plastic; Package Equivalence Code: QFP48,.35SQ,20; Power Supplies: 2.5/3.3V; Qualification Status: Not Qualified; Speed: 72RPM; Time @ Peak Reflow Temperature-Max (s): 30s; Case/Package: LFQFP; Category: Microcontrollers; Component Kind: Standard; ComponentLink1Description: https://datasheet.ciiva.com:443/pdfs/VipMasterIC/IC/SGST/SGST-S-A0001117293/SGST-S-A0001117293-1.pdf?src-supplier=IHS+Markit; ComponentLink1URL: https://datasheet.ciiva.com:443/pdfs/VipMasterIC/IC/SGST/SGST-S-A0001117293/SGST-S-A0001117293-1.pdf?src-supplier=IHS+Markit; Height - Seated (Max): 1.6mm; JESD-30 Code: S-PQFP-G48; JESD-609 Code: e4; Length: 7mm; Library Name: IC_chips.IntLib; Manufacturer Part Number: STM32F103C8T6; Max Operating Temperature: 105°C; Min Operating Temperature: -40°C; Moisture Sensitivity Level: 2; Package Shape: Square; Package Style: FLATPACK, LOW PROFILE, FINE PITCHMeter; Peak Reflow Temperature (Cel): 260°C; Pins: 48; Pricing 1: 1=6.66, 10=6.02, 50=5.74, 100=4.98, 1000=3.69, 10000=3.64 (USD); Reach SVHC Compliant: Yes; RoHS: Yes; RoHS Compliant: Yes; Stock 1: 31617; Supplier 1: Mouser; Supplier Part Number 1: 511-STM32F103C8T6; Surface Mount: Yes; Technology: CMOS; Temperature Grade: INDUSTRIAL; Terminal Finish: Nickel; Terminal Form: Gull Wing; Terminal Pitch: 0.5mm; Terminal Position: QUAD; Width: 7mm
U201 = 74LVC2G240DC,125: Buffer, Inverting 2 Element 1 Bit per Element 3-State Output 8-VSSOP; Manufacturer: Nexperia; Channels: 2; Logic Type: BUS DRIVER; Max Supply Voltage: 5.5V; Min Supply Voltage: 1.65V; Nominal Supply Voltage: 2.7V; Number of Bits: 1; Output Polarity: INVERTED; Package Body Material: Plastic; Propagation Delay (tpd): 11.9ns; Qualification Status: Not Qualified; Time @ Peak Reflow Temperature-Max (s): 30s; Case/Package: VSSOP; Category: Logic Gates; Component Kind: Standard; ComponentLink1Description: https://datasheet.ciiva.com:443/pdfs/VipMasterIC/IC/NEXP/NEXP-S-A0003513156/NEXP-S-A0003513156-1.pdf?src-supplier=IHS+Markit; ComponentLink1URL: https://datasheet.ciiva.com:443/pdfs/VipMasterIC/IC/NEXP/NEXP-S-A0003513156/NEXP-S-A0003513156-1.pdf?src-supplier=IHS+Markit; ComponentLink2Description: https://datasheet.octopart.com/74LVC2G240DC%2C125-Nexperia-datasheet-87580697.pdf?src-supplier=SHENGYU+ELECTRONICS; ComponentLink2URL: https://datasheet.octopart.com/74LVC2G240DC%2C125-Nexperia-datasheet-87580697.pdf?src-supplier=SHENGYU+ELECTRONICS; Height - Seated (Max): 1mm; JESD-30 Code: R-PDSO-G8; JESD-609 Code: e4; Length: 2.3mm; Library Name: IC_chips.IntLib; Manufacturer Part Number: 74LVC2G240DC,125; Max Operating Temperature: 125°C; Min Operating Temperature: -40°C; Moisture Sensitivity Level: 1; Number of Ports: 2; Output Characteristics: 3-STATE; Package Shape: Rectangular; Package Style: SMALL OUTLINE, VERY THIN PROFILE, SHRINK PITCHMeter; Peak Reflow Temperature (Cel): 260°C; Pins: 8; Pricing 1: 1=0.66, 10=0.569, 25=0.5312, 100=0.4249, 250=0.39452, 500=0.33382, 1000=0.25795 (USD); Reach SVHC Compliant: Yes; RoHS: Yes; RoHS Compliant: Yes; Stock 1: 3064; Supplier 1: Digi-Key; Supplier Part Number 1: 1727-7018-1-ND; Surface Mount: Yes; Technology: CMOS; Temperature Grade: AUTOMOTIVE; Terminal Finish: Nickel; Terminal Form: Gull Wing; Terminal Pitch: 0.5mm; Terminal Position: DUAL; Width: 2mm
X200 = ABM8-24.000MHZ-10-B1U-T: 24 MHz ±10ppm Crystal 10pF 50 Ohms 4-SMD, No Lead; Manufacturer: Abracon; Category: Crystals; Component Kind: Standard; ComponentLink1Description: https://datasheet.ciiva.com:443/pdfs/VipMasterIC/IC/ABRC/ABRC-S-A0001358176/ABRC-S-A0001358176-1.pdf?src-supplier=IHS+Markit; ComponentLink1URL: https://datasheet.ciiva.com:443/pdfs/VipMasterIC/IC/ABRC/ABRC-S-A0001358176/ABRC-S-A0001358176-1.pdf?src-supplier=IHS+Markit; ComponentLink2Description: https://datasheet.octopart.com/ABM8-24.000MHZ-10-B1U-T-Abracon-datasheet-154833172.pdf?src-supplier=Sager+Electronics; ComponentLink2URL: https://datasheet.octopart.com/ABM8-24.000MHZ-10-B1U-T-Abracon-datasheet-154833172.pdf?src-supplier=Sager+Electronics; Library Name: Components.IntLib; Manufacturer Part Number: ABM8-24.000MHZ-10-B1U-T; Pricing: 1=0.683, 10=0.564, 25=0.526, 50=0.49, 100=0.456 (USD); RoHS: Yes; Stock: 905; Supplier: Avnet; Supplier Part Number: 01AH9052
